# Altium schematic sheet charger.SchDoc
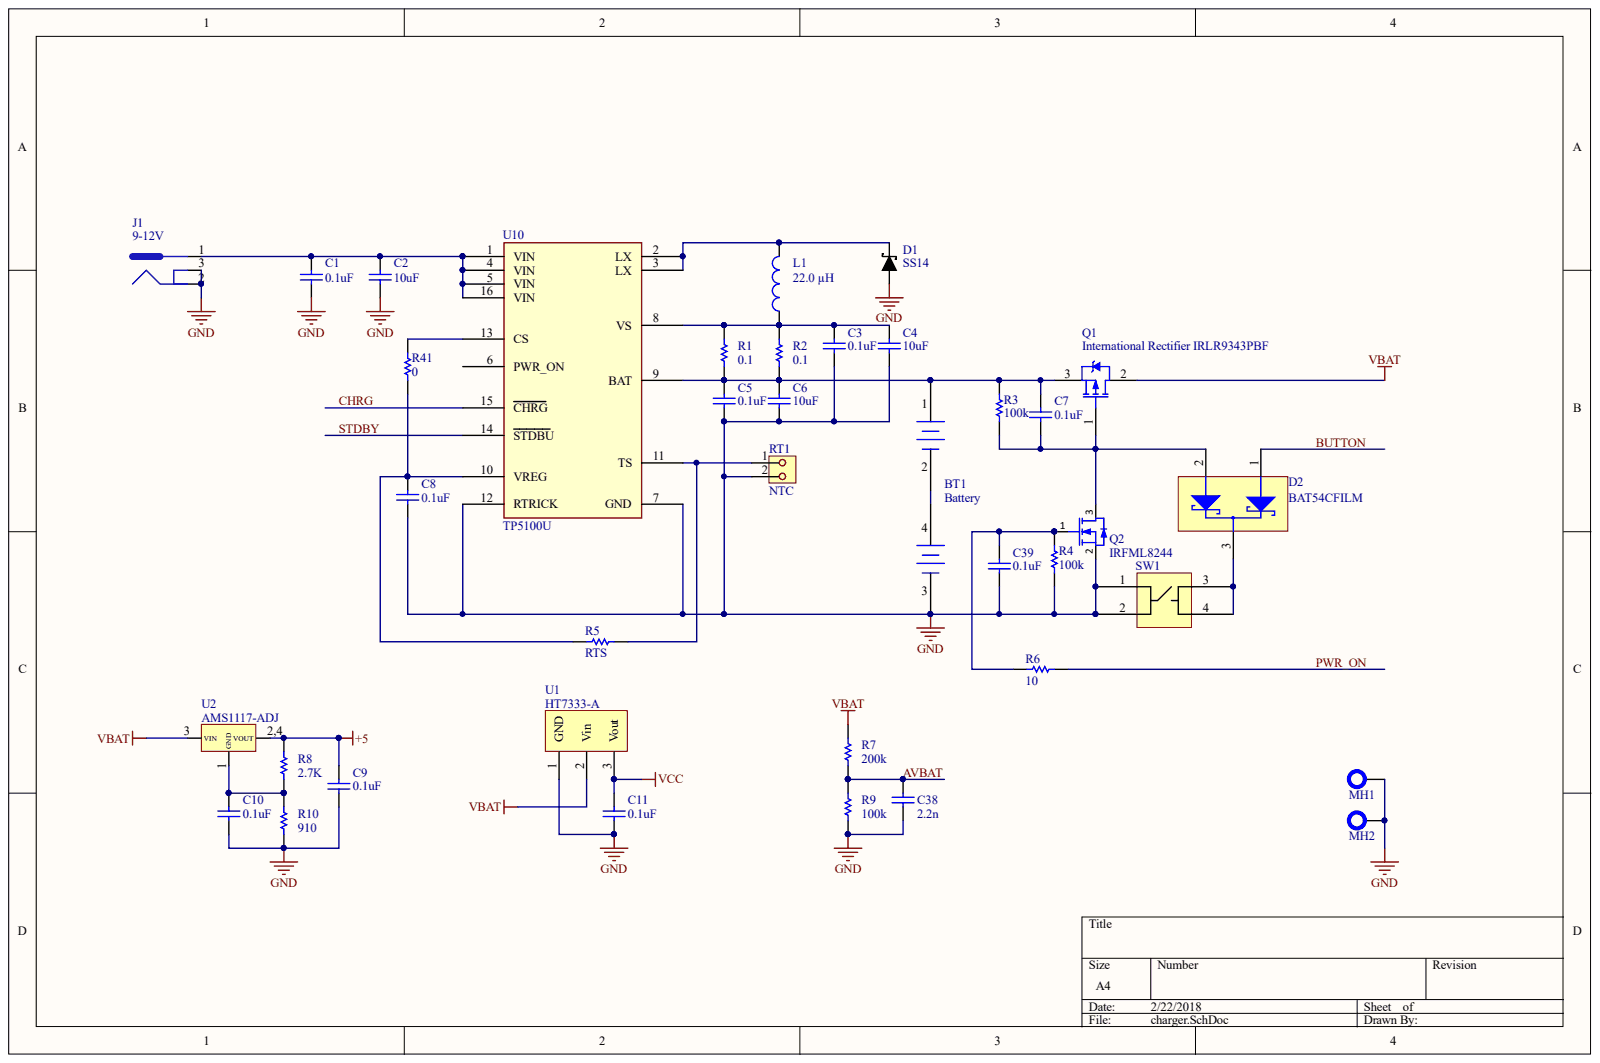
[diagram p0: charger.SchDoc]

## Parts
BT1  Battery  [PCB-s2vm3laqnqbbv3urdljk-1]
C1  Capacitance: 0.1uF  [CAPC1608X90X35LL15T15]  (hidden comment: AVX Interconnect / Elco 06036D105KAT2A)
C2  Capacitance: 10uF  [CAPC2013X140X50ML20T25]  (hidden comment: AVX Interconnect / Elco 08056D106KAT2A)
C3  Capacitance: 0.1uF  [CAPC1608X90X35LL15T15]  (hidden comment: AVX Interconnect / Elco 06036D105KAT2A)
C4  Capacitance: 10uF  [CAPC2013X140X50ML20T25]  (hidden comment: AVX Interconnect / Elco 08056D106KAT2A)
C5  Capacitance: 0.1uF  [CAPC1608X90X35LL15T15]  (hidden comment: AVX Interconnect / Elco 06036D105KAT2A)
C6  Capacitance: 10uF  [CAPC2013X140X50ML20T25]  (hidden comment: AVX Interconnect / Elco 08056D106KAT2A)
C7  Capacitance: 0.1uF  [CAPC1608X90X35LL15T15]  (hidden comment: AVX Interconnect / Elco 06036D105KAT2A)
C8  Capacitance: 0.1uF  [CAPC1608X90X35LL15T15]  (hidden comment: AVX Interconnect / Elco 06036D105KAT2A)
C9  Capacitance: 0.1uF  [CAPC1608X90X35LL15T15]  (hidden comment: AVX Interconnect / Elco 06036D105KAT2A)
C10  Capacitance: 0.1uF  [CAPC1608X90X35LL15T15]  (hidden comment: AVX Interconnect / Elco 06036D105KAT2A)
C11  Capacitance: 0.1uF  [CAPC1608X90X35LL15T15]  (hidden comment: AVX Interconnect / Elco 06036D105KAT2A)
C38  Capacitance: 2.2n  [CAPC1608X90X35LL15T15]  (hidden comment: AVX Interconnect / Elco 06036D105KAT2A)
C39  Capacitance: 0.1uF  [CAPC1608X90X35LL15T15]  (hidden comment: AVX Interconnect / Elco 06036D105KAT2A)
D1  SS14  [SMB]
D2  Manufacturer Part Number: BAT54CFILM  [PCB-l1lmzftcqe25cysua8mc-1]  (hidden comment: Diode)
J1  9-12V  [PCB-sg4td6wdnbcx32schpkh-1]
L1  Inductor  [PCB-nnnro8dea2m4q1g7ugdq-1]  (value not drawn)
MH1  MountHole  [PCB-d0jqlyxt4wqhvgbnry4h-1]  (value not drawn)
MH2  MountHole  [PCB-d0jqlyxt4wqhvgbnry4h-1]  (value not drawn)
Q1  International Rectifier IRLR9343PBF  [PCB-7ekshzshouqvitj38941-1]
Q2  IRFML8244  [PCB-2559974-1]
R1  Resistance: 0.1  [PCB-7w67gjgbmz27625n7m9y-1]  (hidden comment: Panasonic ERJ-S6SFR10V)
R2  Resistance: 0.1  [PCB-7w67gjgbmz27625n7m9y-1]  (hidden comment: Panasonic ERJ-S6SFR10V)
R3  Resistance: 100k  [RESC1609X50X30ML10T20]  (hidden comment: Vishay Dale CRCW0603200KFKEA)
R4  Resistance: 100k  [RESC1609X50X30ML10T20]  (hidden comment: Vishay Dale CRCW0603200KFKEA)
R5  Resistance: RTS  [RESC1609X50X30ML10T20]  (hidden comment: Vishay Dale CRCW0603200KFKEA)
R6  Vishay Dale CRCW0603200KFKEA  [RESC1609X50X30ML10T20]  (value not drawn)
R7  Resistance: 200k  [RESC1609X50X30ML10T20]  (hidden comment: Vishay Dale CRCW0603200KFKEA)
R8  Resistance: 2.7K  [RESC1609X50X30ML10T20]  (hidden comment: Vishay Dale CRCW0603200KFKEA)
R9  Resistance: 100k  [RESC1609X50X30ML10T20]  (hidden comment: Vishay Dale CRCW0603200KFKEA)
R10  Vishay Dale CRCW0603200KFKEA  [RESC1609X50X30ML10T20]  (value not drawn)
R41  Panasonic ERA-3AED153V  [RESC1608X55X30NL20T20]  (value not drawn)
RT1  NTC  [PCB-55llon6gpk2h6a06nz0l-1]
SW1  E-Switch TL3301NF160QG  [pushbutton]  (value not drawn)
U1  HT7333-A  [SOT-89_M]
U2  AMS1117-ADJ  [PCB-p6hf3r2io0qiochncded-1]
U10  TP5100U  [TI-RGT16-1650X1650TP_V]

## Nets
+5: C9.2 R8.2 U2.2,4
GND: BT1.3 C1.1 C2.1 C3.1 C4.1 C5.1 C6.1 C8.1 C9.1 C10.1 C11.1 C38.1 C39.1 D1.2 J1.2 J1.3 MH1.1 MH2.1 Q2.2 R4.2 R9.1 R10.1 RT1.2 SW1.1 SW1.2 U1.1 U10.7(GND) U10.12(RTRICK)
VBAT: Q1.2 R7.2 U1.2 U2.3
VCC: C11.2 U1.3
AVBAT: C38.2 R7.1 R9.2
BUTTON: D2.1
CHRG: U10.15(CHRG)
PWR_ON: R6.2
STDBY: U10.14(STDBU)
NetBT1_1: BT1.1 C5.2 C6.2 C7.2 Q1.3 R1.1 R2.1 R3.1 U10.9(BAT)
NetBT1_2: BT1.2 BT1.4
NetC1_2: C1.2 C2.2 J1.1 U10.1(VIN) U10.4(VIN) U10.5(VIN) U10.16(VIN)
NetC3_2: C3.2 C4.2 L1.1 R1.2 R2.2 U10.8(VS)
NetC7_1: C7.1 D2.2 Q1.1 Q2.3 R3.2
NetC8_2: C8.2 R5.2 R41.2 U10.10(VREG)
NetC10_2: C10.2 R8.1 R10.2 U2.1
NetC39_2: C39.2 Q2.1 R4.1 R6.1
NetD1_1: D1.1 L1.2 U10.2(LX) U10.3(LX)
NetD2_3: D2.3 SW1.3 SW1.4
NetR5_1: R5.1 RT1.1 U10.11(TS)
NetR41_1: R41.1 U10.13(CS)

Not connected: U10 6(PWR_ON)

## Library data of the parts
BT1 = CMP-c3280b12305a1cef-15: OTC (1048) Mechanical Grip-O-Matic Puller - 25 Ton, Long 2 Jaw. 25 ton capacity, long 2 jaw. Maximum reach of 22-1/4 ...; Component Kind: Standard; Library Name: CommunityVault; OctopartID: c3280b12305a1cef; RoHS: Compliant
C1 C3 C5 C7-C11 C38 C39 = CMP-126022-2: CAP CER 1UF 6.3V 10% X5R 0603; Dielectric Material: Ceramic Multilayer; Insulation Resistance: 1e+11 Ω; Lead-Free Status: Lead Free; Capacitance Tolerance: ±10%; Case/Package: 0603; Component Kind: Standard; Dielectric Characteristic: X5R; Library Name: CommunityVault; Mounting Style: Surface Mount; Number of Pins: 2; OctopartID: 12fddbf79c04cd3a; Octopart Url: https://octopart.com/06036d105kat2a-avx+interconnect+%2F+elco-43935; REACH SVHC Compliance: No SVHC; RoHS: Compliant; Size-Height: 0.00086 m; Size-Length: 0.0016 m; Size-Thickness: 0.0008636 m; Size-Width: 0.00081 m; Voltage Rating (DC): 6.3 V
C2 C4 C6 = CMP-126447-4: CAP CER 10UF 6.3V 10% X5R 0805; Case/Package (SI): 2012; Dielectric Material: Ceramic Multilayer; Insulation Resistance: 500000000.0 Ω; Lead-Free Status: Lead Free; Capacitance Tolerance: ±10%; Case/Package: 0805; Component Kind: Standard; Dielectric Characteristic: X5R; Library Name: CommunityVault; Mounting Style: Surface Mount; Number of Pins: 2; OctopartID: 4d4d8447e98a928a; Octopart Url: https://octopart.com/08056d106kat2a-avx+interconnect+%2F+elco-33185; REACH SVHC Compliance: No SVHC; RoHS: Compliant; Size-Height: 0.0014 m; Size-Length: 0.00201 m; Size-Thickness: 0.001397 m; Size-Width: 0.00125 m; Voltage Rating (DC): 6.3 V
D1 = CMP-814764-5: DIODE SCHOTTKY 40V 1A SMA; Current Rating: 1.0 A; Lead-Free Status: Lead Free; Polarity: Standard; Voltage Rating (DC): 40.0 V; Case/Package: DO-214; Component Kind: Standard; Library Name: CommunityVault; Lifecycle Status: Not Listed by Manufacturer; Mounting Style: Surface Mount; Number of Pins: 2; OctopartID: ce2a046ddb5d11ed; Octopart Url: https://octopart.com/ss14-fairchild+semiconductor-55390225; REACH SVHC Compliance: No SVHC; RoHS: Compliant
D2 = CMP-c2df0bd114d11d18-1: Diode, Schottky, 40V, 0.3A, array, common-cathode, SOT23-3; Capacitance: 10.0 pF; Current Rating: 300 mA; Forward Voltage: 400 mV; Lead-Free Status: Lead Free; Voltage Rating (DC): 30.0 V; Case/Package: SOT-23; Component Kind: Standard; Library Name: CommunityVault; Lifecycle Status: Active; Mounting Style: Surface Mount; Number of Pins: 3; OctopartID: c2df0bd114d11d18; Octopart URL: http://octopart.com/bat54cfilm-stmicroelectronics-324456; Packaging: Cut Tape (CT); RoHS: Compliant
J1 = CMP-1e49d460fd2bc18e-1: DC Power jack [Würth Elektronik] 694106301002 DC Power jack; Current Rating: 5.00 A; Gender: Male; Voltage Rating (DC): 20.0 V; Color: Black; Component Kind: Standard; Library Name: CommunityVault; Mounting Style: Through Hole; OctopartID: 1e49d460fd2bc18e; RoHS: Compliant
L1 = CMP-b8a071cc71126767-1: Power inductor, shielded, 10/20/30% tol, SMT, RoHS; Manufacturer Part Number: MSS1048-223MLC; Inductance: 22.0 µH; Lead-Free Status: Contains Lead; Self Resonant Frequency: 12.0 MHz; Component Kind: Standard; Library Name: CommunityVault; Lifecycle Status: Active; Mounting Style: Surface Mount; OctopartID: b8a071cc71126767; Octopart URL: http://octopart.com/mss1048-223mlc-coilcraft-6745300; Packaging: Tape & Reel (TR), Cut Tape (CT); REACH SVHC Compliance: No SVHC; RoHS: Compliant
MH1 MH2 = CMP-eo2hwbm1pte8m6tn8ao3-1: M3 mounthole with space for 5mm dia stands; Component Kind: Standard; CustomComponentID: eo2hwbm1pte8m6tn8ao3; Library Name: FavoritesLibrary
Q1 = CMP-9eeb57e48a4c412c-2: Single P-Channel 55 V 79 W 31 nC Power Mosfet Surface Mount - TO-252-3; Continuous Drain Current (Ids): 20.0 A; Current Rating: -20.0 A; Drain to Source Voltage (Vds): 55.0 V; Lead-Free Status: Lead Free; Rise Time: 2.4e-08 s; Voltage Rating (DC): -55.0 V; Case/Package: TO-252; Component Kind: Standard; Library Name: CommunityVault; Lifecycle Status: Active; Manufacturer Part Number: IRLR9343PBF; Mounting Style: Surface Mount; Number of Pins: 3; OctopartID: 9eeb57e48a4c412c; Octopart URL: http://octopart.com/irlr9343pbf-international+rectifier-340417; Packaging: Tube; Part Family: IRLR9343; Polarity: P-Channel; REACH SVHC Compliance: No SVHC; RoHS: Compliant
Q2 = CMP-2559974-6: Trans MOSFET N-CH 25V 5.8A 3-Pin SOT-23 T/R; Lead-Free Status: Lead Free; Polarity: N-Channel; Power Dissipation: 1.25 W; Component Kind: Standard; Library Name: CommunityVault; Lifecycle Status: Active; Mounting Style: Surface Mount; Number of Pins: 3; OctopartID: 10b1d89ad6afcf76; Octopart Url: https://octopart.com/irfml8244trpbf-international+rectifier-18998136; Packaging: Cut Tape (CT); Part Family: IRFML8244; REACH SVHC Compliance: No SVHC; RoHS: Compliant
R1 R2 = CMP-1d26d515c31ec2e1-1: SMD Current Sense Resistors, AEC-Q200 ERJ Series, 0.1 ohm, 250 mW, 1%, 0805 [2012 Metric]; Power Rating: 250 mW; Case/Package: 0805, 2012; Component Kind: Standard; Library Name: CommunityVault; Lifecycle Status: Not Listed by Manufacturer; Manufacturer Part Number: ERJ-S6SFR10V; OctopartID: 1d26d515c31ec2e1; Octopart URL: http://octopart.com/erj-s6sfr10v-panasonic-20577118; Packaging: Cut Tape (CT); REACH SVHC Compliance: No SVHC; Resistance Tolerance: ±1%; RoHS: Compliant
R3-R5 R7-R9 = CMP-1857245-4: RES SMD 200K OHM 1% 1/10W 0603; Lead-Free Status: Lead Free; Power Rating: 0.1 W; Temperature Coefficient: 100.0 ppm/°C; Voltage Rating (DC): 75.0 V; Case/Package: 0603; Component Kind: Standard; Library Name: CommunityVault; Mounting Style: Surface Mount; Number of Pins: 2; OctopartID: 353afe6f23fdeafd; Octopart Url: https://octopart.com/crcw0603200kfkea-vishay-39793569; REACH SVHC Compliance: No SVHC; Resistance Tolerance: ±1%; RoHS: Compliant; Size-Height: 0.00045 m; Size-Length: 0.00155 m; Size-Width: 0.00085 m
R6 = CMP-1857245-4: RES SMD 200K OHM 1% 1/10W 0603; Lead-Free Status: Lead Free; Power Rating: 0.1 W; Resistance: 10; Temperature Coefficient: 100.0 ppm/°C; Voltage Rating (DC): 75.0 V; Case/Package: 0603; Component Kind: Standard; Library Name: CommunityVault; Mounting Style: Surface Mount; Number of Pins: 2; OctopartID: 353afe6f23fdeafd; Octopart Url: https://octopart.com/crcw0603200kfkea-vishay-39793569; REACH SVHC Compliance: No SVHC; Resistance Tolerance: ±1%; RoHS: Compliant; Size-Height: 0.00045 m; Size-Length: 0.00155 m; Size-Width: 0.00085 m
R10 = CMP-1857245-4: RES SMD 200K OHM 1% 1/10W 0603; Lead-Free Status: Lead Free; Power Rating: 0.1 W; Resistance: 910; Temperature Coefficient: 100.0 ppm/°C; Voltage Rating (DC): 75.0 V; Case/Package: 0603; Component Kind: Standard; Library Name: CommunityVault; Mounting Style: Surface Mount; Number of Pins: 2; OctopartID: 353afe6f23fdeafd; Octopart Url: https://octopart.com/crcw0603200kfkea-vishay-39793569; REACH SVHC Compliance: No SVHC; Resistance Tolerance: ±1%; RoHS: Compliant; Size-Height: 0.00045 m; Size-Length: 0.00155 m; Size-Width: 0.00085 m
R41 = CMP-4023581-2: PANASONIC   ERA-3AED153V   THIN FILM, RESISTOR, 15KOHM, 100mW, 0.5%; Power Rating: 0.1 W; Resistance: 0; Voltage Rating (DC): 75.0 V; Component Kind: Standard; Library Name: CommunityVault; Lifecycle Status: Not Listed by Manufacturer; OctopartID: 54079c681bee8bc0; Octopart Url: https://octopart.com/era-3aed153v-panasonic-23423631; Packaging: Cut Tape (CT); Resistance Tolerance: ±0.5%; RoHS: Compliant
RT1 = CMP-8fae46aeaafe5069-11: 2.54mm (.100") Pitch C-Grid III Header, Single Row, Vertical, 1 Circuits, Gold (Au) Plating; Manufacturer Part Number: 90120-0762; Contact Resistance: 0.02 ?; Current Rating: 3.0 A; Gender: Male; Glow Wire Compliant: Non-Compliant; Insulation Resistance: 5000000000.0 ?; Lead-Free Status: Lead Free; Number of Circuits: 1; Number of Contacts: 2; Pin Pitch: 0.00254 m; Voltage Rating (AC): 350.0 V; Component Kind: Standard; Contact Material: Brass; Contact Plating: Gold; Halogen Free Status: Not Reviewed; Housing Color: Black; Housing Material: Plastic; Library Name: CommunityVault; Lifecycle Status: Not Listed by Manufacturer; Mounting Style: Through Hole, Vertical; Number of Positions: 2; Number of Rows: 1; OctopartID: 8fae46aeaafe5069; Octopart URL: http://octopart.com/90120-0762-molex-655414; Orientation: Vertical; Packaging: Bag; RoHS: Compliant; Size-Height: 0.0025 m; Size-Length: 0.00508 m; Size-Width: 0.00254 m; Voltage Rating (DC): 350.0 V
SW1 = CMP-1507dc1d1294cac0-1: TL3301 Series SPST 1.6 N Round Button Gull Wing Surface Mount Tactile Switch; Actuator Type: Standard; Contact Current Rating: 0.05 A; Contacts Type: SPST-NO; Lead-Free Status: Lead Free; Supply Voltage (DC): 12.0 V; Component Kind: Standard; Contact Plating: Silver; Library Name: CommunityVault; Manufacturer Part Number: TL3301NF160QG; Mounting Style: Surface Mount; OctopartID: 1507dc1d1294cac0; Octopart URL: http://octopart.com/tl3301nf160qg-e-switch-1057525; Orientation: Vertical; Packaging: Reel; RoHS: Compliant; Size-Length: 0.006 m; Size-Width: 0.006 m
U1 = CMP-f9d135d015f72616-1: Fixed Low Drop Positive Voltage Regulator, 3.3V, 4-Pin SOT-89, 3-Pin TO-02, Tape and Reel; Maximum input voltage: 12V; Maximum Output Current: 250mA; Component Kind: Standard; Library Name: CommunityVault
U2 = CMP-b2ec7a7365b06d2b-2: Sot223/Pkg 1-Amp 5.0-Volt Low Drop Out Voltage Regulatator; Component Kind: Standard; Library Name: CommunityVault
U10 = CMP-84a4vnvdco9x5389ktav-2: 2S Li-Ion Charger; Component Kind: Standard; CustomComponentID: 84a4vnvdco9x5389ktav; Library Name: CommunityVault
